# Altium schematic sheet 07b_V2.3.sch
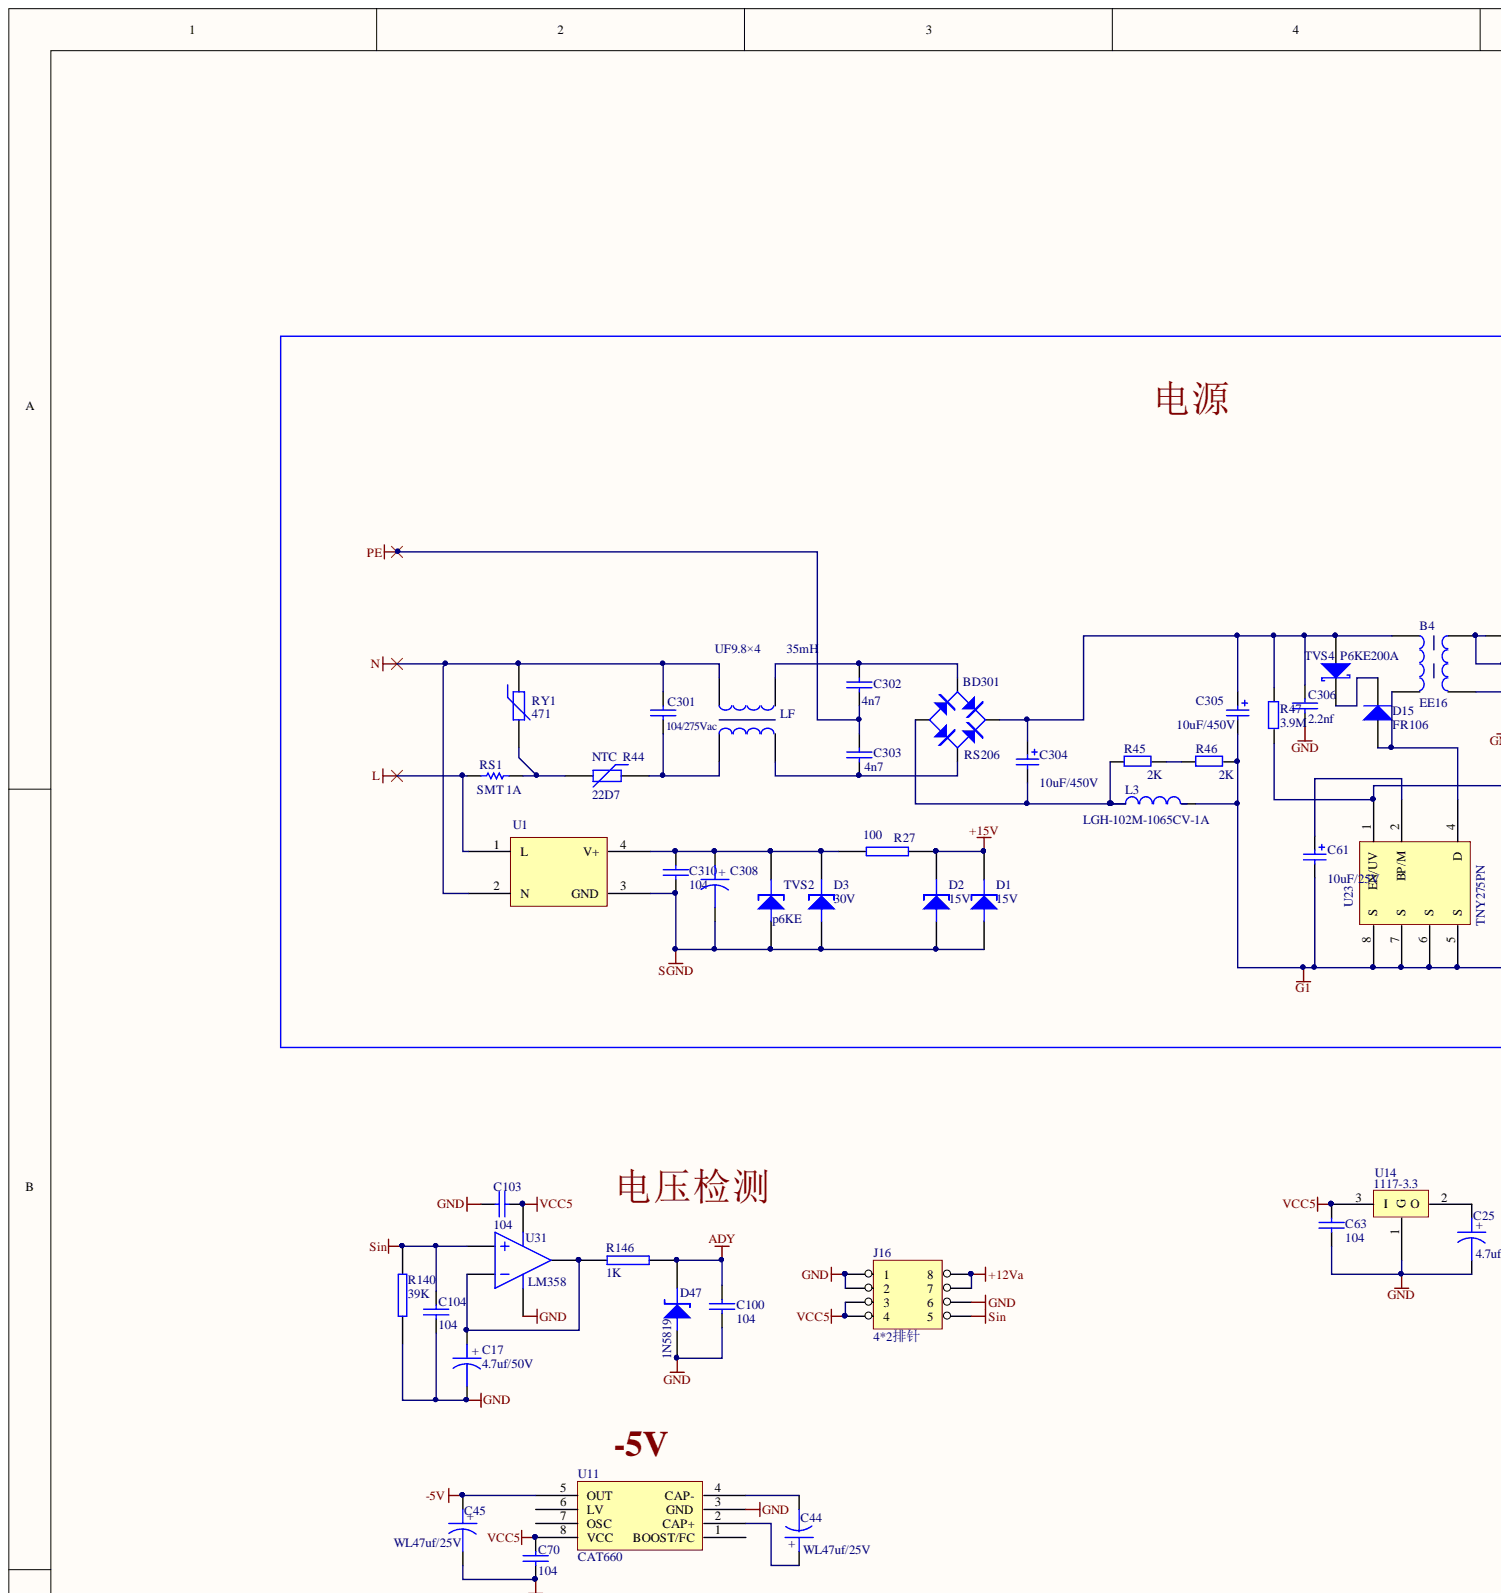
[diagram p0: 07b_V2.3.sch, top left]
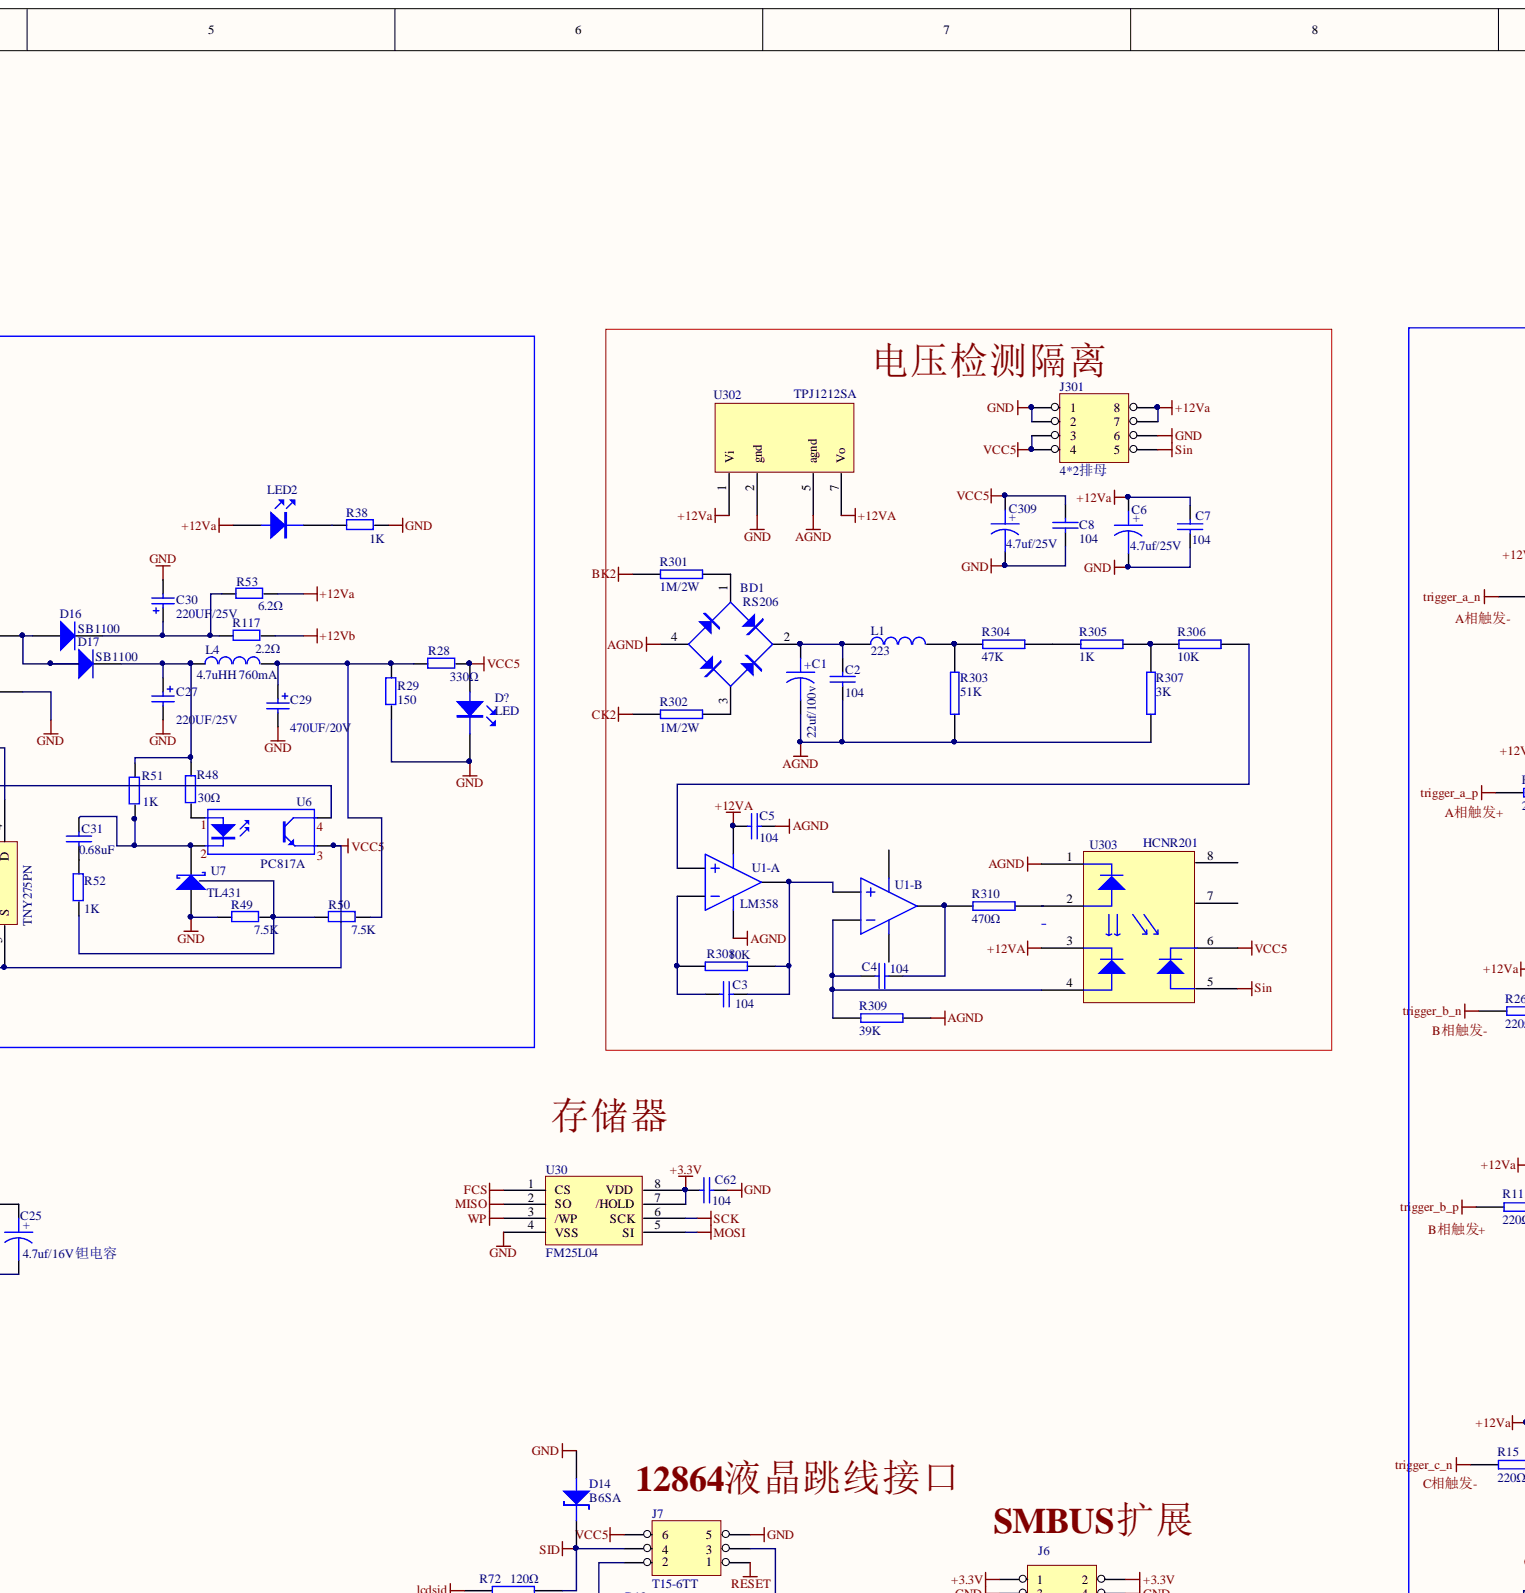
[diagram p1: 07b_V2.3.sch, top centre]
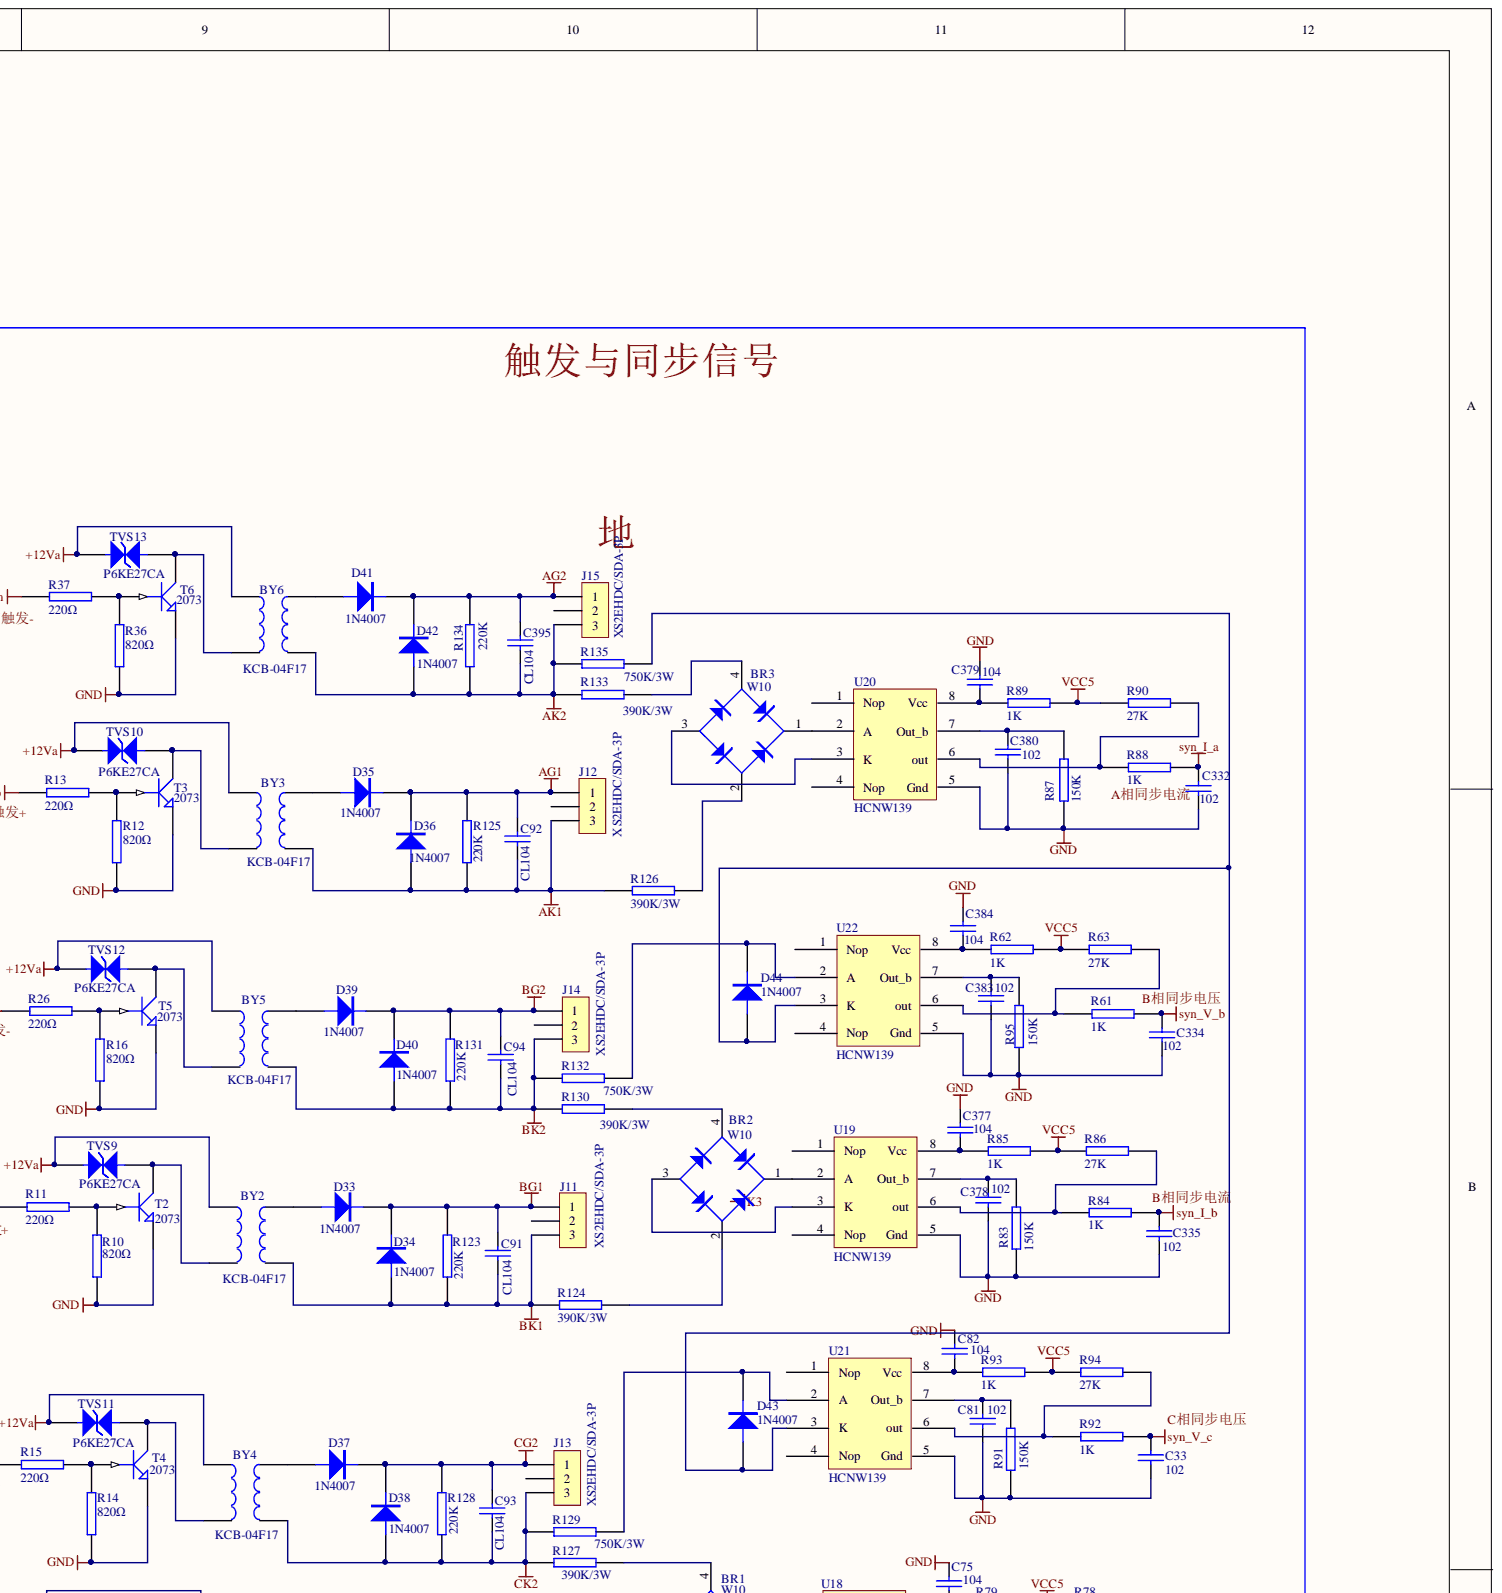
[diagram p2: 07b_V2.3.sch, top right]
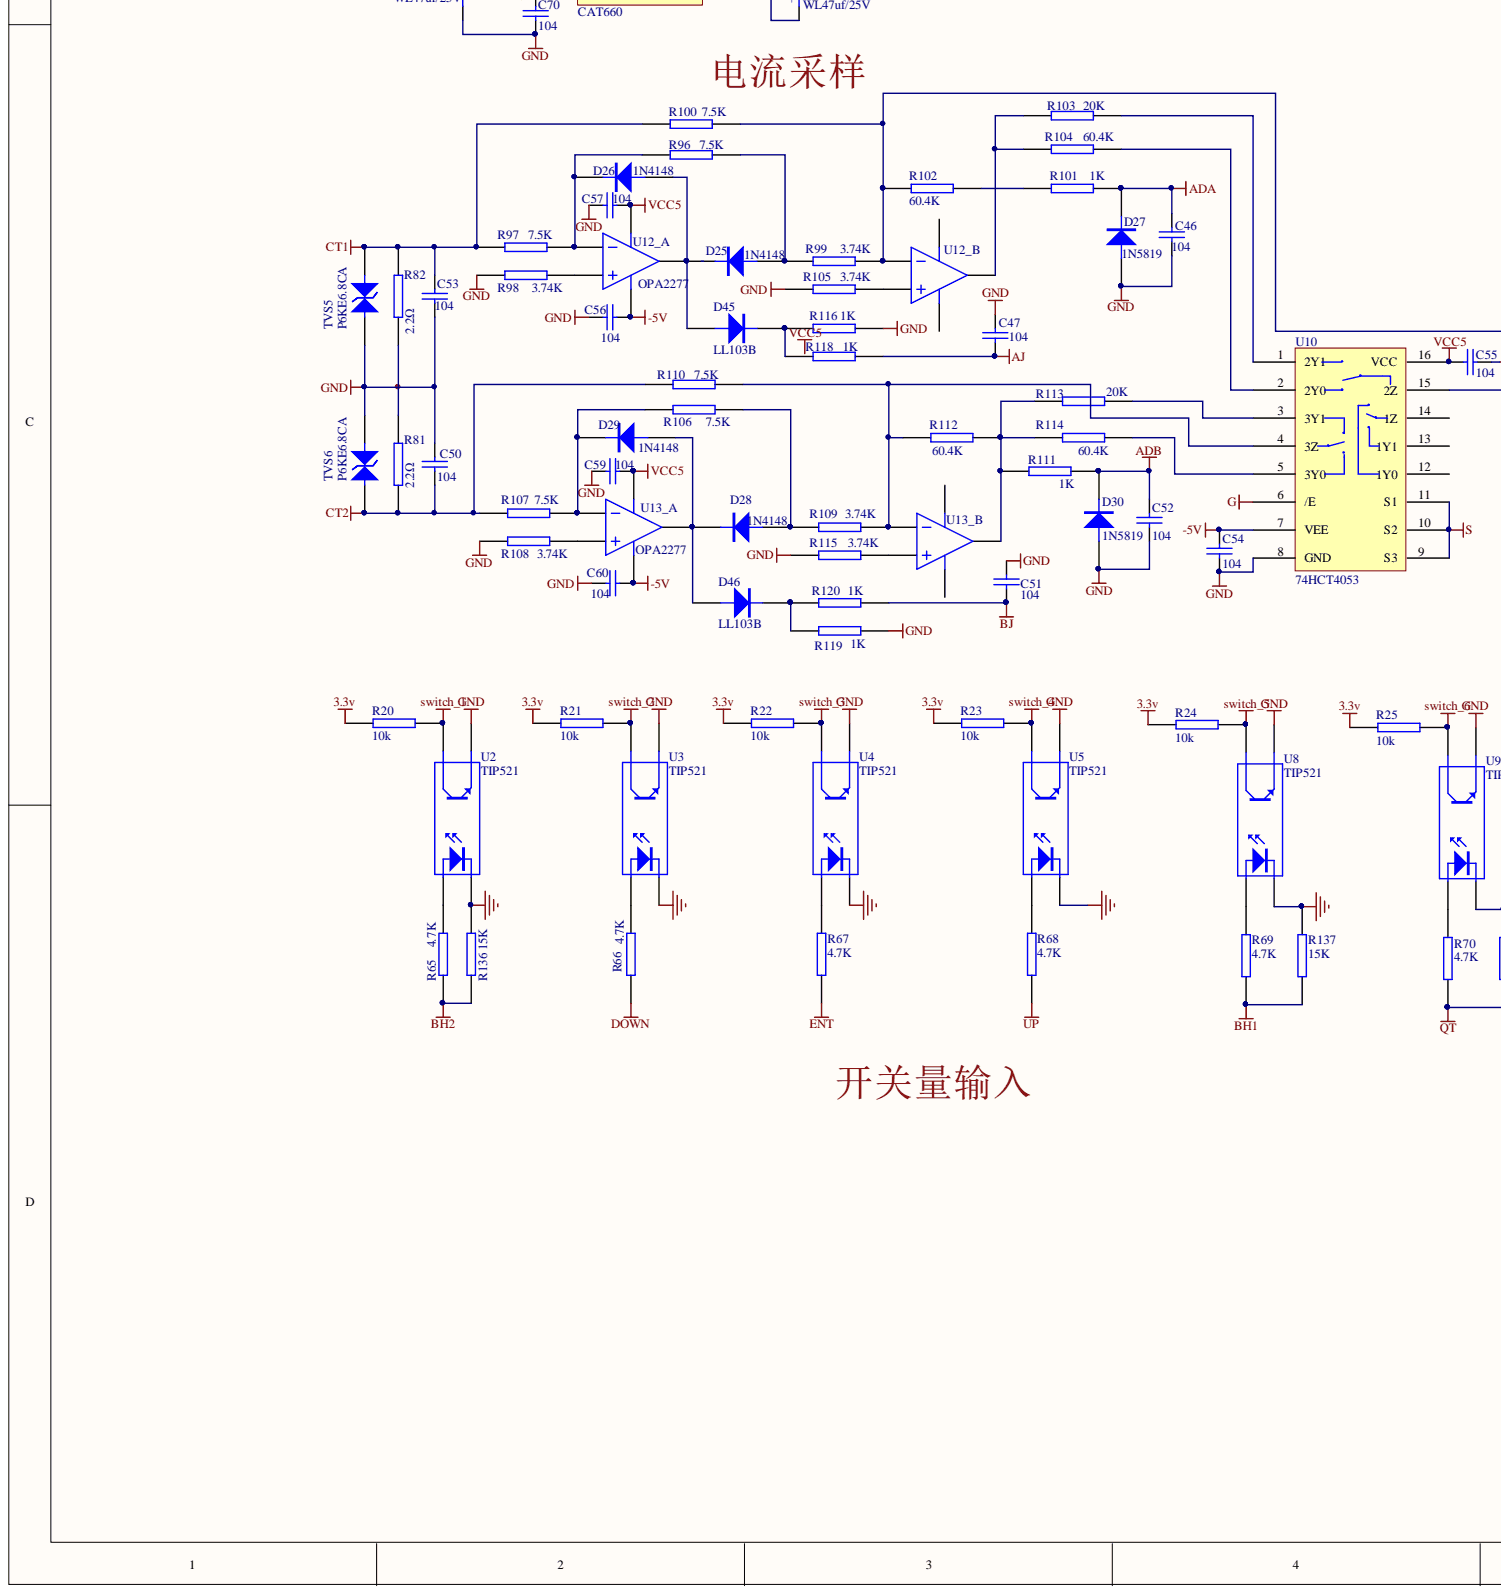
[diagram p3: 07b_V2.3.sch, bottom left]
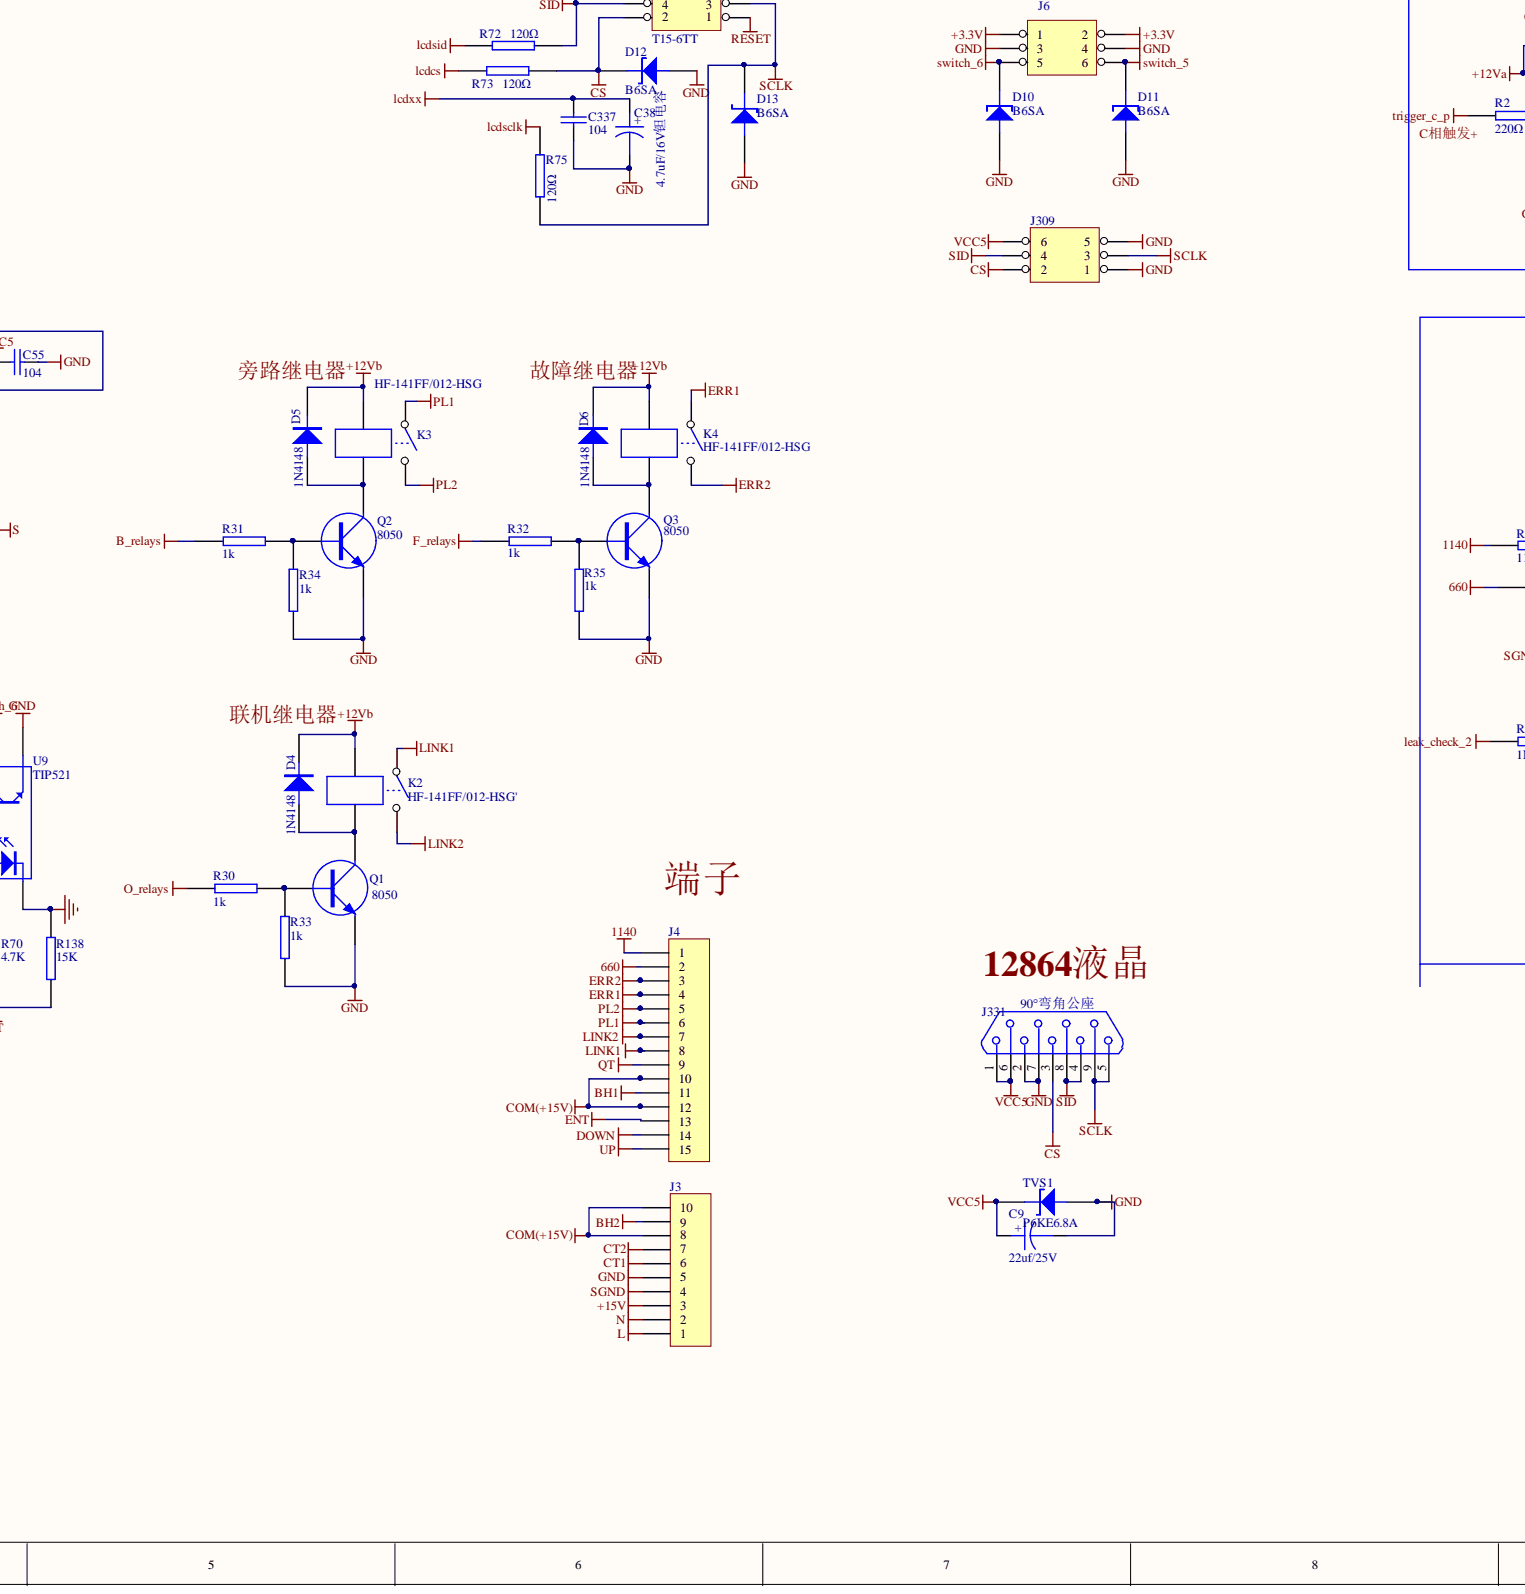
[diagram p4: 07b_V2.3.sch, bottom centre]
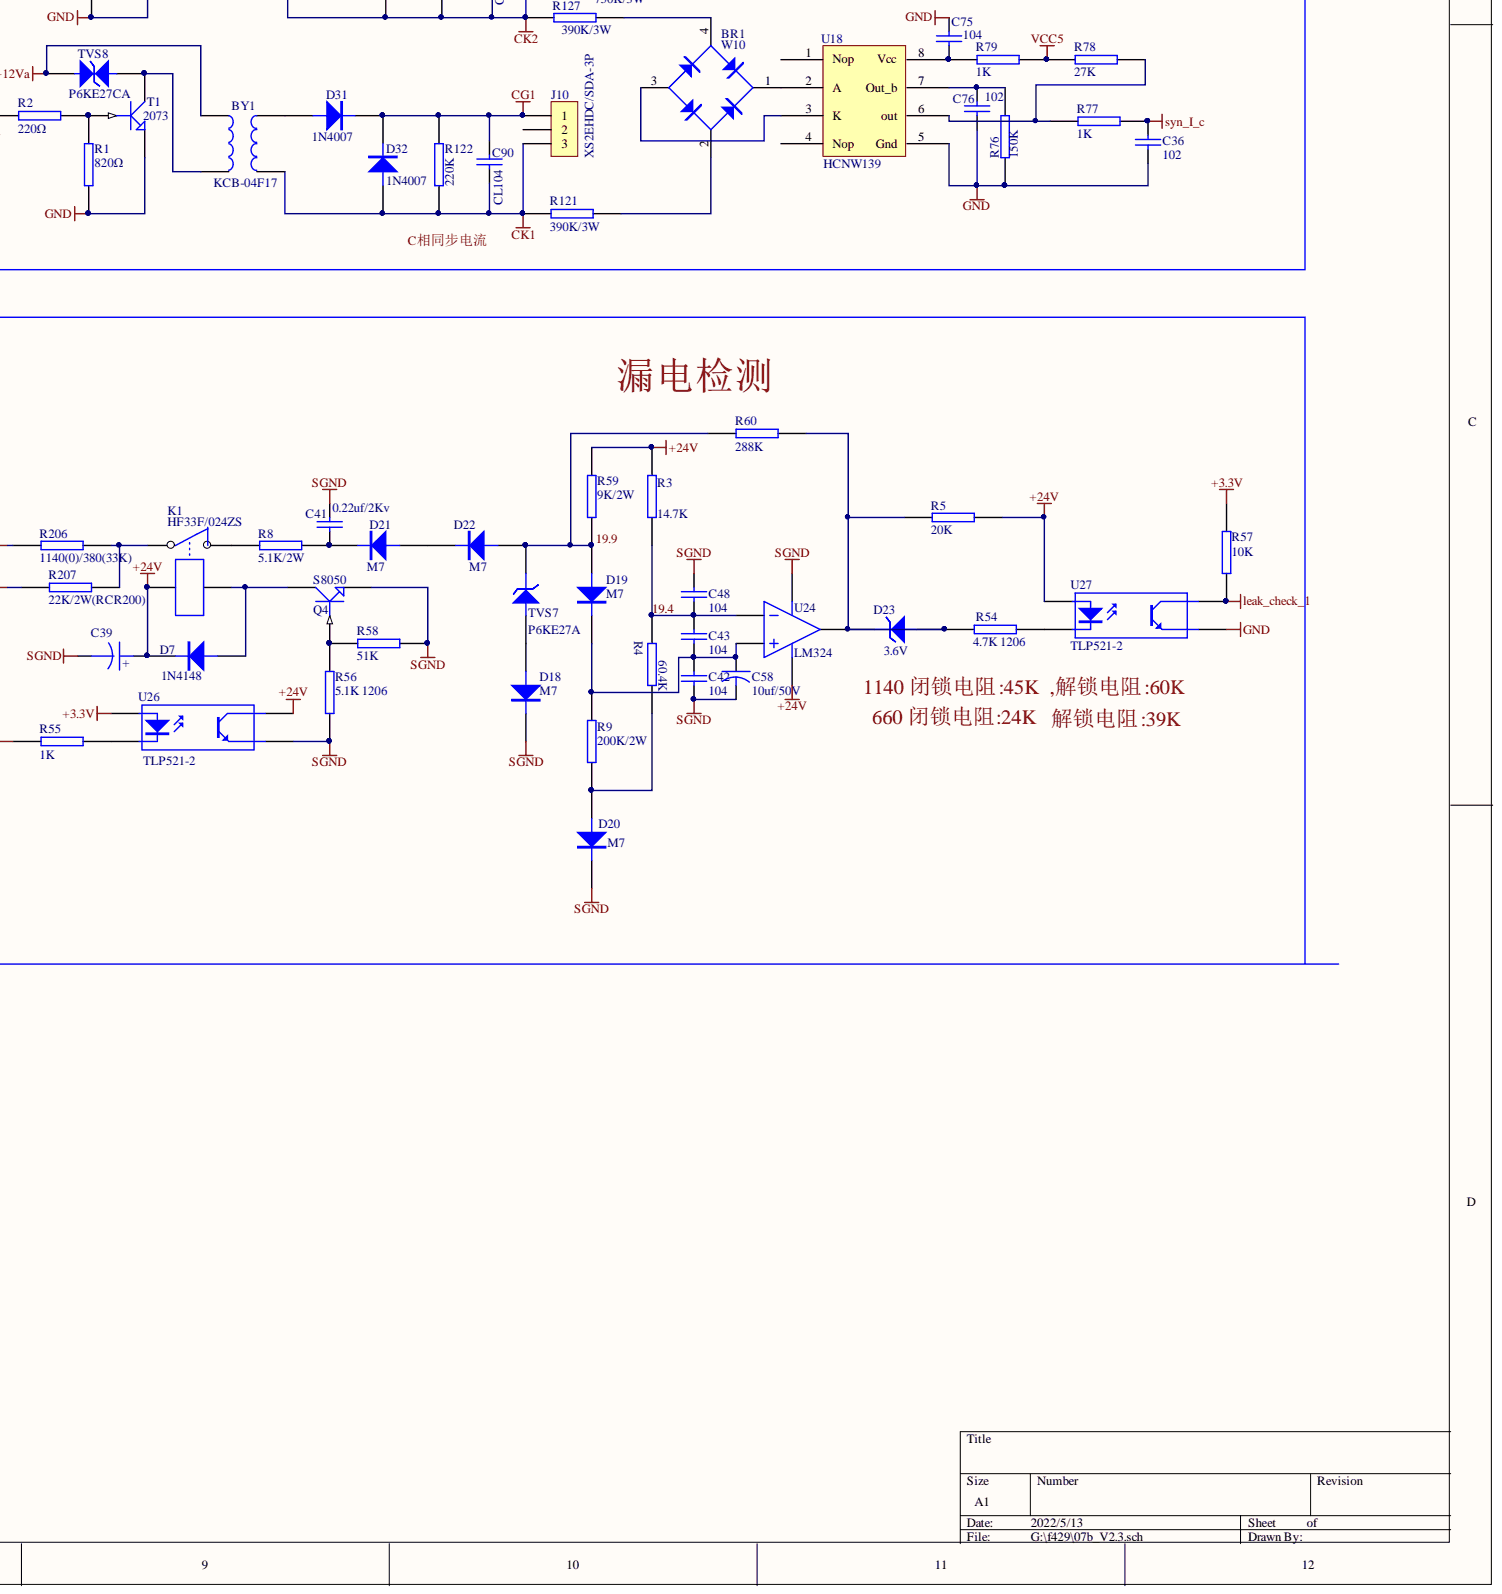
[diagram p5: 07b_V2.3.sch, bottom right]

## Parts
B4  EE16  [TRANS]
BD1  RS206  [BRIDGE]
BD301  RS206
BR1  W10  [BRIDGE]
BR2  W10  [BRIDGE]
BR3  W10  [BRIDGE]
BY1  KCB-04F17
BY2  KCB-04F17
BY3  KCB-04F17
BY4  KCB-04F17
BY5  KCB-04F17
BY6  KCB-04F17
C1  22uf/100v
C2  104
C3  104
C4  104
C5  104
C6  4.7uf/25V
C7  104
C8  104
C9  22uf/25V
C17  4.7uf/50V
C25  4.7uf/16V钽电容  [CC3225-1210]
C27  value 220UF/25V  [POLAR0.8]  (hidden comment: Cap Pol2)
C29  value 470UF/20V  [POLAR0.8]  (hidden comment: Cap Pol2)
C30  value 220UF/25V  [POLAR0.8]  (hidden comment: Cap Pol2)
C31  0.68uF
C33  102
C36  102
C38  4.7uF/16V钽电容
C39  (no value)
C41  0.22uf/2Kv
C42  104
C43  104
C44  WL47uf/25V
C45  WL47uf/25V
C46  104
C47  104
C48  104
C50  104
C51  104
C52  104
C53  104
C54  104
C55  104
C56  104
C57  104
C58  10uf/50V
C59  104
C60  104
C61  value 10uF/25V  [POLAR0.8]  (hidden comment: Cap Pol2)
C62  104
C63  104
C70  104
C75  104
C76  102
C81  102
C82  104
C90  CL104
C91  CL104
C92  CL104
C93  CL104
C94  CL104
C100  104
C103  104
C104  104
C301  104/275Vac
C302  4n7
C303  4n7
C304  value 10uF/450V  [POLAR0.8]  (hidden comment: Cap Pol2)
C305  value 10uF/450V  [POLAR0.8]  (hidden comment: Cap Pol2)
C306  2.2nf
C308  (no value)
C309  4.7uf/25V
C310  104
C332  102
C334  102
C335  102
C337  104
C377  104
C378  102
C379  104
C380  102
C383  102
C384  104
C395  CL104
D1  15V
D2  15V
D3  30V
D4  1N4148
D5  1N4148
D6  1N4148
D7  1N4148
D10  B6SA
D11  B6SA
D12  B6SA
D13  B6SA
D14  B6SA
D15  FR106  [SMC]
D16  SB1100  [SMC]
D17  SB1100  [SMC]
D18  M7
D19  M7
D20  M7
D21  M7
D22  M7
D23  3.6V
D25  1N4148
D26  1N4148
D27  1N5819
D28  1N4148
D29  1N4148
D30  1N5819
D31  1N4007
D32  1N4007
D33  1N4007
D34  1N4007
D35  1N4007
D36  1N4007
D37  1N4007
D38  1N4007
D39  1N4007
D40  1N4007
D41  1N4007
D42  1N4007
D43  1N4007
D44  1N4007
D45  LL103B
D46  LL103B
D47  1N5819
D?  LED
J3  (no value)
J4  (no value)
J6  (no value)
J7  T15-6TT
J10  XS2EHDC/SDA-3P
J11  XS2EHDC/SDA-3P
J12  XS2EHDC/SDA-3P
J13  XS2EHDC/SDA-3P
J14  XS2EHDC/SDA-3P
J15  XS2EHDC/SDA-3P
J16  4*2排针
J301  4*2排母
J309  (no value)
J331  90°弯角公座  [DB-9/M]
K1  HF33F/024ZS
K2  HF-141FF/012-HSG'
K3  HF-141FF/012-HSG
K4  HF-141FF/012-HSG
L1  223
L3  LGH-102M-1065CV-1A  [INDC1005-0402]
L4  4.7uHH 760mA  [INDC1005-0402]
LED2  (no value)
LF  UF9.8×4          35mH  [TRANS]
NTC_R44  22D7  [CR2012-0805]
Q1  8050
Q2  8050
Q3  8050
Q4  S8050
R1  820Ω
R2  220Ω
R3  14.7K
R4  60.4K
R5  20K
R8  5.1K/2W
R9  200K/2W
R10  820Ω
R11  220Ω
R12  820Ω
R13  220Ω
R14  820Ω
R15  220Ω
R16  820Ω
R20  10k
R21  10k
R22  10k
R23  10k
R24  10k
R25  10k
R26  220Ω
R27  100
R28  value 330Ω  [AXIAL-0.4]  (hidden comment: Res2)
R29  value 150  [AXIAL-0.4]  (hidden comment: Res2)
R30  1k
R31  1k
R32  1k
R33  1k
R34  1k
R35  1k
R36  820Ω
R37  220Ω
R38  value 1K  [AXIAL-0.4]  (hidden comment: Res2)
R45  value 2K  [AXIAL-0.4]  (hidden comment: Res2)
R46  value 2K  [AXIAL-0.4]  (hidden comment: Res2)
R47  value 3.9M  [AXIAL-0.4]  (hidden comment: Res2)
R48  value 30Ω  [AXIAL-0.4]  (hidden comment: Res2)
R49  value 7.5K  [AXIAL-0.4]  (hidden comment: Res2)
R50  value 7.5K  [AXIAL-0.4]  (hidden comment: Res2)
R51  value 1K  [AXIAL-0.4]  (hidden comment: Res2)
R52  value 1K  [AXIAL-0.4]  (hidden comment: Res2)
R53  value 6.2Ω  [AXIAL-0.4]  (hidden comment: Res2)
R54  4.7K 1206
R55  1K
R56  5.1K 1206
R57  10K
R58  51K
R59  9K/2W
R60  288K
R61  1K
R62  1K
R63  27K
R65  4.7K
R66  4.7K
R67  4.7K
R68  4.7K
R69  4.7K
R70  4.7K
R72  120Ω
R73  120Ω
R75  120Ω
R76  150K
R77  1K
R78  27K
R79  1K
R81  2.2Ω
R82  2.2Ω
R83  150K
R84  1K
R85  1K
R86  27K
R87  150K
R88  1K
R89  1K
R90  27K
R91  150K
R92  1K
R93  1K
R94  27K
R95  150K
R96  7.5K
R97  7.5K
R98  3.74K
R99  3.74K
R100  7.5K
R101  1K
R102  60.4K
R103  20K
R104  60.4K
R105  3.74K
R106  7.5K
R107  7.5K
R108  3.74K
R109  3.74K
R110  7.5K
R111  1K
R112  60.4K
R113  20K
R114  60.4K
R115  3.74K
R116  1K
R117  value 2.2Ω  [AXIAL-0.4]  (hidden comment: Res2)
R118  1K
R119  1K
R120  1K
R121  390K/3W
R122  220K
R123  220K
R124  390K/3W
R125  220K
R126  390K/3W
R127  390K/3W
R128  220K
R129  750K/3W
R130  390K/3W
R131  220K
R132  750K/3W
R133  390K/3W
R134  220K
R135  750K/3W
R136  15K
R137  15K
R138  15K
R140  39K
R146  1K
R206  1140(0)/380(33K)
R207  22K/2W(RCR200)
R301  1M/2W
R302  1M/2W
R303  51K
R304  47K
R305  1K
R306  10K
R307  3K
R308  10K  (value not drawn)
R309  39K
R310  470Ω
RS1  SMT 1A  [AXIAL-0.3]
RY1  471  [CR2012-0805]
T1  2073
T2  2073
T3  2073
T4  2073
T5  2073
T6  2073
TVS1  P6KE6.8A
TVS2  p6KE
TVS4  P6KE200A  [SFM-T2(3)/X1.7V]
TVS5  P6KE6.8CA
TVS6  P6KE6.8CA
TVS7  P6KE27A
TVS8  P6KE27CA
TVS9  P6KE27CA
TVS10  P6KE27CA
TVS11  P6KE27CA
TVS12  P6KE27CA
TVS13  P6KE27CA
U1  (no value)
U1-A  LM358
U1-B  (no value)
U2  TIP521
U3  TIP521
U4  TIP521
U5  TIP521
U6  PC817A  [DIP-4]
U7  TL431  [SFM-T2(3)/X1.7V]
U8  TIP521
U9  TIP521
U10  74HCT4053
U11  CAT660
U12_A  OPA2277
U12_B  (no value)
U13_A  OPA2277
U13_B  (no value)
U14  1117-3.3  [DSO-G3]
U18  HCNW139
U19  HCNW139
U20  HCNW139
U21  HCNW139
U22  HCNW139
U23  TNY275PN
U24  LM324
U26  TLP521-2
U27  TLP521-2
U30  FM25L04
U31  LM358
U302  TPJ1212SA
U303  HCNR201

## Nets
3.3v: R20.1 R21.1 R22.1 R23.1 R24.2 R25.1
660: J4.2 R207.1
1140: J4.1 R206.1
+3.3V: C62.1 J6.1 J6.2 R57.2 U26.1 U30.7(/HOLD) U30.8(VDD)
+12Va: BY1.1 BY2.1 BY3.1 BY4.1 BY5.1 BY6.1 C5.1 C6.1 C7.1 J16.2 J16.4 J301.2 J301.4 LED2.A R53.2 TVS8.2 TVS9.2 TVS10.2 TVS11.2 TVS12.2 TVS13.2 U1-A.5 U302.1(Vi) U302.7(Vo) U303.3
+12Vb: D4.2 D5.2 D6.2 K2.3 K3.3 K4.3 R117.2
+15V: D1.2 D2.2 J3.3 R27.2
+24V: C39.1 D7.2 K1.3 R3.2 R5.1 R59.1 U24.5 U26.3 U27.1
ADA: C46.1 D27.2 R101.2
ADB: C52.1 D30.2 R111.2
ADY: C100.1 D47.2 R146.2
AG1: C92.1 D35.2 D36.2 J12.1 R125.2
AG2: C395.1 D41.2 D42.2 J15.1 R134.2
AGND: BD1.4 C1.2 C2.2 C5.2 R303.1 R307.1 R309.1 U1-A.4 U302.5(agnd) U303.1
AJ: C47.2 R118.1
AK1: BY3.4 C92.2 D36.1 J12.3 R125.1 R126.1
AK2: BY6.4 C395.2 D42.1 J15.3 R133.1 R134.1 R135.1
B_relays: R31.1
BG1: C91.1 D33.2 D34.2 J11.1 R123.2
BG2: C94.1 D39.2 D40.2 J14.1 R131.2
BH1: J4.11 R69.2 R137.1
BH2: J3.9 R65.2 R136.1
BJ: C51.2 R120.2
BK1: BY2.4 C91.2 D34.1 J11.3 R123.1 R124.1
BK2: BY5.4 C94.2 D40.1 J14.3 R130.1 R131.1 R132.1 R301.1
CG1: C90.1 D31.2 D32.2 J10.1 R122.2
CG2: C93.1 D37.2 D38.2 J13.1 R128.2
CK1: BY1.4 C90.2 D32.1 J10.3 R121.1 R122.1
CK2: BY4.4 C93.2 D38.1 J13.3 R127.1 R128.1 R129.1 R302.1
COM(+15V): J3.8 J3.10 J4.10 J4.12
CS: D12.2 J7.2 J309.2 J331.3 R73.2
CT1: C53.2 J3.6 R82.2 R97.1 R100.1 TVS5.2
CT2: C50.1 J3.7 R81.1 R107.1 R110.1 TVS6.2
DOWN: J4.14 R66.2
ENT: J4.13 R67.2
ERR1: J4.4 K4.1
ERR2: J4.3 K4.2
F_relays: R32.1
FCS: U30.1(CS)
G: U10.6(/E)
G1: C8.1 C9.1 C55.2 C57.2 C59.2 C61.2 C63.1 C70.1 C103.1 C305.2 C309.1 D?.A J7.6 J16.5 J16.7 J301.5 J301.7 J309.6 J331.1 J331.6 L3.2 R28.2 R46.2 R62.2 R63.1 R78.1 R79.2 R85.2 R86.1 R89.2 R90.1 R93.2 R94.1 TVS1.2 U6.3 U10.16(VCC) U11.8(VCC) U12_A.4 U13_A.4 U14.3 U23.5(S) U23.6(S) U23.7(S) U23.8(S) U31.5 U303.6
GND: B4.4 C6.2 C7.2 C8.2 C9.2 C17.2 C25.2 C27.2 C29.2 C30.2 C33.2 C36.2 C38.2 C45.2 C46.2 C47.1 C50.2 C51.1 C52.2 C53.1 C54.1 C55.1 C56.1 C57.1 C59.1 C60.1 C62.2 C63.2 C70.2 C75.1 C76.2 C81.2 C82.1 C100.2 C103.2 C104.2 C306.2 C309.2 C332.2 C334.2 C335.2 C337.2 C377.1 C378.2 C379.1 C380.2 C383.2 C384.1 D10.1 D11.1 D12.1 D13.1 D14.1 D27.1 D30.1 D47.1 D?.K J3.5 J6.3 J6.4 J7.5 J16.1 J16.2 J16.6 J301.1 J301.2 J301.6 J309.1 J309.5 J331.2 J331.7 Q1.3 Q2.3 Q3.3 R1.1 R10.1 R12.1 R14.1 R16.1 R29.2 R33.1 R34.1 R35.1 R36.1 R38.2 R49.1 R76.2 R81.2 R82.1 R83.2 R87.2 R91.2 R95.2 R98.1 R105.1 R108.1 R115.1 R116.2 R119.2 R140.1 T1.3 T2.3 T3.3 T4.3 T5.3 T6.3 TVS1.1 TVS5.2 TVS6.2 U2.4 U3.4 U4.4 U5.4 U7.3 U8.4 U9.4 U10.8(GND) U11.3(GND) U14.1 U18.5(Gnd) U19.5(Gnd) U20.5(Gnd) U21.5(Gnd) U22.5(Gnd) U27.4 U30.4(VSS) U31.4 U302.2(gnd)
L: J3.1 RS1.1 U1.1(L)
lcdcs: R73.1
lcdsclk: R75.1
lcdsid: R72.1
lcdxx: C38.1 C337.1
leak_check_1: R57.1 U27.3
leak_check_2: R55.1
LINK1: J4.8 K2.1
LINK2: J4.7 K2.2
MISO: U30.2(SO)
MOSI: U30.5(SI)
N: C301.1 J3.2 LF.3 RY1.1 U1.2(N)
O_relays: R30.1
PE: C302.2 C303.1
PL1: J4.6 K3.1
PL2: J4.5 K3.2
QT: J4.9 R70.2 R138.1
RESET: J7.1
S: U10.9(S3) U10.10(S2) U10.11(S1)
SCK: U30.6(SCK)
SCLK: D13.2 J7.3 J309.3 J331.5 J331.9 R75.2
SGND: C39.2 C41.2 C42.2 C48.1 C58.2 C308.2 C310.2 D1.1 D2.1 D3.1 D18.2 D20.2 J3.4 Q4.3 R56.1 R58.2 TVS2.1 U1.3(GND) U24.4 U26.4
SID: D14.2 J7.4 J309.4 J331.4 J331.8 R72.2
Sin: C104.1 J16.8 J301.8 R140.2 U31.2 U303.5
switch_1: R20.2 U2.3
switch_2: R21.2 U3.3
switch_3: R22.2 U4.3
switch_4: R23.2 U5.3
switch_5: D11.2 J6.6 R24.1 U8.3
switch_6: D10.2 J6.5 R25.2 U9.3
syn_I_a: C332.1 R88.2
syn_I_b: C335.1 R84.2
syn_I_c: C36.1 R77.2
syn_V_b: C334.1 R61.2
syn_V_c: C33.1 R92.2
trigger_a_n: R37.1
trigger_a_p: R13.1
trigger_b_n: R26.1
trigger_b_p: R11.1
trigger_c_n: R15.1
trigger_c_p: R2.1
UP: J4.15 R68.2
VCC: R136.2 R137.2 R138.2 U2.2 U3.2 U4.2 U5.2 U8.2 U9.2
WP: U30.3(/WP)
19.4: C43.1 C48.2 R3.1 R4.2 U24.1
-5V: C45.1 C54.2 C56.2 C60.2 U10.7(VEE) U11.5(OUT) U12_A.5 U13_A.5
NetB4_1: B4.1 BD301.3 C304.1 C305.1 C306.1 R47.1 TVS4.3
NetB4_2: B4.2 D15.1 U23.4(D)
NetB4_3: B4.3 D16.1 D17.1
NetBD1_1: BD1.1 R301.2
NetBD1_2: BD1.2 C1.1 C2.1 L1.1
NetBD1_3: BD1.3 R302.2
NetBD301_1: BD301.1 C304.2 L3.1 R45.1
NetBD301_2: BD301.2 C302.1 LF.4
NetBD301_4: BD301.4 C303.2 LF.2
NetBR1_1: BR1.1 U18.2(A)
NetBR1_2: BR1.2 R121.2
NetBR1_3: BR1.3 U18.3(K)
NetBR1_4: BR1.4 R127.2
NetBR2_1: BR2.1 U19.2(A)
NetBR2_2: BR2.2 R124.2
NetBR2_3: BR2.3 U19.3(K)
NetBR2_4: BR2.4 R130.2
NetBR3_1: BR3.1 U20.2(A)
NetBR3_2: BR3.2 R126.2
NetBR3_3: BR3.3 U20.3(K)
NetBR3_4: BR3.4 R133.2
NetBY1_2: BY1.2 D31.1
NetBY1_3: BY1.3 T1.2 TVS8.2
NetBY2_2: BY2.2 D33.1
NetBY2_3: BY2.3 T2.2 TVS9.2
NetBY3_2: BY3.2 D35.1
NetBY3_3: BY3.3 T3.2 TVS10.2
NetBY4_2: BY4.2 D37.1
NetBY4_3: BY4.3 T4.2 TVS11.2
NetBY5_2: BY5.2 D39.1
NetBY5_3: BY5.3 T5.2 TVS12.2
NetBY6_2: BY6.2 D41.1
NetBY6_3: BY6.3 T6.2 TVS13.2
NetC3_1: C3.1 R308.1 U1-A.1
NetC3_2: C3.2 R308.2 U1-A.3 U1-B.2
NetC4_1: C4.1 R309.2 U1-B.1 U303.4
NetC4_2: C4.2 R310.1 U1-B.3
NetC17_1: C17.1 R146.1 U31.1 U31.3
NetC25_1: C25.1 U14.2
NetC27_1: C27.1 D17.2 L4.1 R48.2 R51.2
NetC29_1: C29.1 L4.2 R28.1 R29.1 R50.2
NetC30_1: C30.1 D16.2 R53.1 R117.1
NetC31_1: C31.1 R51.1 U6.2 U7.1
NetC31_2: C31.2 R52.2
NetC41_1: C41.1 D21.2 R8.2
NetC42_1: C42.1 C43.2 C58.1 D19.2 R9.2 U24.2
NetC44_1: C44.1 U11.2(CAP+)
NetC44_2: C44.2 U11.4(CAP-)
NetC61_1: C61.1 U23.2(BP/M)
NetC75_2: C75.2 R79.1 U18.8(Vcc)
NetC76_1: C76.1 R76.1 U18.7(Out_b)
NetC81_1: C81.1 R91.1 U21.7(Out_b)
NetC82_2: C82.2 R93.1 U21.8(Vcc)
NetC301_2: C301.2 LF.1 NTC_R44.1
NetC308_1: C308.1 C310.1 D3.2 R27.1 TVS2.2 U1.4(V+)
NetC377_2: C377.2 R85.1 U19.8(Vcc)
NetC378_1: C378.1 R83.1 U19.7(Out_b)
NetC379_2: C379.2 R89.1 U20.8(Vcc)
NetC380_1: C380.1 R87.1 U20.7(Out_b)
NetC383_1: C383.1 R95.1 U22.7(Out_b)
NetC384_2: C384.2 R62.1 U22.8(Vcc)
NetD4_1: D4.1 K2.4 Q1.2
NetD5_1: D5.1 K3.4 Q2.2
NetD6_1: D6.1 K4.4 Q3.2
NetD7_1: D7.1 K1.4 Q4.2
NetD15_2: D15.2 TVS4.1
NetD18_1: D18.1 TVS7.1
NetD19_1: D19.1 D22.1 R59.2 R60.1 TVS7.2
NetD20_1: D20.1 R4.1 R9.1
NetD21_1: D21.1 D22.2
NetD23_1: D23.1 R5.2 R54.1 R60.2 U24.3
NetD25_1: D25.1 R96.2 R99.1
NetD25_2: D25.2 D26.1 D45.1 U12_A.3
NetD26_2: D26.2 R96.1 R97.2 U12_A.1
NetD28_1: D28.1 R106.2 R109.1
NetD28_2: D28.2 D29.1 D46.1 U13_A.3
NetD29_2: D29.2 R106.1 R107.2 U13_A.1
NetD43_1: D43.1 D44.1 R135.2 U21.3(K) U22.3(K)
NetD43_2: D43.2 R129.2 U21.2(A)
NetD44_2: D44.2 R132.2 U22.2(A)
NetD45_2: D45.2 R116.1 R118.2
NetD46_2: D46.2 R119.1 R120.1
NetK1_1: K1.1 R206.2 R207.2
NetK1_2: K1.2 R8.1
NetL1_2: L1.2 R303.2 R304.1
NetLED2_K: LED2.K R38.1
NetNTC  R44_2: NTC_R44.2 RS1.2 RY1.2
NetQ1_1: Q1.1 R30.2 R33.2
NetQ2_1: Q2.1 R31.2 R34.2
NetQ3_1: Q3.1 R32.2 R35.2
NetQ4_1: Q4.1 R56.2 R58.1
NetR1_2: R1.2 R2.2 T1.1
NetR10_2: R10.2 R11.2 T2.1
NetR12_2: R12.2 R13.2 T3.1
NetR14_2: R14.2 R15.2 T4.1
NetR16_2: R16.2 R26.2 T5.1
NetR36_2: R36.2 R37.2 T6.1
NetR45_2: R45.2 R46.1
NetR47_2: R47.2 U6.4 U23.1(EN/UV)
NetR48_1: R48.1 U6.1
NetR49_2: R49.2 R50.1 R52.1
NetR54_2: R54.2 U27.2
NetR55_2: R55.2 U26.2
NetR61_1: R61.1 R63.2 U22.6(out)
NetR65_1: R65.1 U2.1
NetR66_1: R66.1 U3.1
NetR67_1: R67.1 U4.1
NetR68_1: R68.1 U5.1
NetR69_1: R69.1 U8.1
NetR70_1: R70.1 U9.1
NetR77_1: R77.1 R78.2 U18.6(out)
NetR84_1: R84.1 R86.2 U19.6(out)
NetR88_1: R88.1 R90.2 U20.6(out)
NetR92_1: R92.1 R94.2 U21.6(out)
NetR98_2: R98.2 U12_A.2
NetR99_2: R99.2 R100.2 R102.1 U10.15(2Z) U12_B.1
NetR101_1: R101.1 R102.2
NetR103_1: R103.1 U10.1(2Y1)
NetR103_2: R103.2 R104.1 U12_B.3
NetR104_2: R104.2 U10.2(2Y0)
NetR105_2: R105.2 U12_B.2
NetR108_2: R108.2 U13_A.2
NetR109_2: R109.2 R110.2 R112.1 U10.4(3Z) U13_B.1
NetR111_1: R111.1 R112.2 R113.1 R114.1 U13_B.3
NetR113_2: R113.2 U10.3(3Y1)
NetR114_2: R114.2 U10.5(3Y0)
NetR115_2: R115.2 U13_B.2
NetR304_2: R304.2 R305.2
NetR305_1: R305.1 R306.1 R307.2
NetR306_2: R306.2 U1-A.2
NetR310_2: R310.2 U303.2
1:
2:
3:
4:
19.9:
660 闭锁电阻:24K:
1140 闭锁电阻:45K:
12864液晶:
12864液晶跳线接口:
,解锁电阻:60K:
A相同步电流:
A相触发+:
A相触发-:
B相同步电压:
B相同步电流:
B相触发+:
B相触发-:
C相同步电压:
C相同步电流:
C相触发+:
C相触发-:
K3:
N00216:
N00217:
N00218:
N00219:
N00220:
SMBUS扩展:
地:
存储器:
开关量输入:
故障继电器:
旁路继电器:
漏电检测:
电压检测:
电压检测隔离:
电流采样:
电源:
端子:
联机继电器:
解锁电阻:39K:
触发与同步信号:

Not connected: D23 2; J10 2; J11 2; J12 2; J13 2; J14 2; J15 2; U1-B 4 5; U10 12(1Y0) 13(1Y1) 14(1Z); U11 1(BOOST/FC) 6(LV) 7(OSC); U12_B 4 5; U13_B 4 5; U18 1(Nop) 4(Nop); U19 1(Nop) 4(Nop); U20 1(Nop) 4(Nop); U21 1(Nop) 4(Nop); U22 1(Nop) 4(Nop); U303 7 8

## Library data of the parts
B4 LF = Trans: Transformer; Component Kind: Standard; LatestRevisionDate: 17-Jul-2002; LatestRevisionNote: Re-released for DXP Platform.; Published: 24-Mar-1999; Publisher: Altium Limited
BD1 BR1-BR3 = BRIDGE1: Diode Bridge; Component Kind: Standard
BD301 = 18DB05: Diode Bridge; Component Kind: Standard
BY1-BY6 = TRANS3: Component Kind: Standard
C1 C6 C9 C17 C25 C38 C39 C44 C45 C308 C309 = CAPACITOR POL: Capacitor; Component Kind: Standard
C2-C5 C7 C8 C31 C33 C36 C41-C43 C46-C48 C50-C57 C59 C60 C62 C63 C70 C75 C76 C81 C82 C90-C94 C100 C103 C104 C301-C303 C306 C310 C332 C334 C335 C337 C377-C380 C383 C384 C395 = CAP: Capacitor; Component Kind: Standard
C27 C29 C30 C61 C304 C305 = Cap Pol2: Polarized Capacitor (Axial); Component Kind: Standard; LatestRevisionDate: 17-Jul-2002; LatestRevisionNote: Re-released for DXP Platform.; Library Name: Miscellaneous Devices.IntLib; PackageDescription: Polarized Capacitor; 2 Leads; PackageReference: POLAR0.8; Published: 8-Jun-2000; Signal Integrity: Cap Pol; Simulation: CAP; Publisher: Altium Limited
C58 = CAPACITOR: Component Kind: Standard; Description: Capacitor
D1-D3 D10 D11 TVS2 = TUNNEL: Tunnel FDiode; Component Kind: Standard
D4-D7 D18-D22 D25-D46 = DIODE: Component Kind: Standard; Description: Diode
D12-D14 D47 TVS1 = ZENER2: Zener Diode; Component Kind: Standard
D15-D17 = Diode: Default Diode; Code_JEDEC: DO-214-AB; Component Kind: Standard; LatestRevisionDate: 17-Jul-2002; LatestRevisionNote: Re-released for DXP Platform.; Library Name: Miscellaneous Devices.IntLib; PackageDescription: DO-214-AB/SMC; 2 C-Bend Leads; Body 7.9 x 5.9 mm, inc. leads (LxW); PackageReference: SMC; PackageVersion: Sep-1996; Published: 8-Jun-2000; Signal Integrity: Diode; Simulation: DIODE; Publisher: Altium Limited
D23 TVS7 = ZENER3: Zener Diode; Component Kind: Standard
J3 = CON10: Connector; Component Kind: Standard
J4 = CON15: Connector; Component Kind: Standard
J6 J7 J309 = HEADER 3X2: Component Kind: Standard
J10-J15 = CON3: Connector; Component Kind: Standard
J16 J301 = HEADER 4X2: Component Kind: Standard
J331 = DB9: Component Kind: Standard
K1 U303 = Component_1: Component Kind: Standard
K2-K4 = RELAY-SPST: Component Kind: Standard
L1 = INDUCTOR: Component Kind: Standard
L3 L4 = Inductor: Code_IEC: 1005; Code_JEITA: 0402; Component Kind: Standard; Description: Inductor; LatestRevisionDate: 17-Jul-2002; LatestRevisionNote: Re-released for DXP Platform.; PackageReference: 0402-A; Published: 8-Jun-2000; Publisher: Altium Limited
LED2 = LED: Component Kind: Standard
NTC_R44 RY1 = Res Varistor: Varistor (Voltage-Sensitive Resistor); Component Kind: Standard; LatestRevisionDate: 4-Mar-2005; LatestRevisionNote: PCB Footprint replaced.; Published: 24-Mar-1999; Publisher: Altium Limited
Q1-Q3 = NPN: NPN Transistor; Component Kind: Standard
Q4 T1-T6 = NPN1: NPN Transistor; Component Kind: Standard
R1-R5 R8-R16 R20-R27 R30-R37 R54-R63 R65-R70 R72 R73 R75-R79 R81-R116 R118-R138 R140 R146 R206 R207 R301-R310 = RES2: Component Kind: Standard
R28 R29 R38 R45-R53 R117 = Res2: Resistor; Component Kind: Standard; LatestRevisionDate: 17-Jul-2002; LatestRevisionNote: Re-released for DXP Platform.; Library Name: Miscellaneous Devices.IntLib; PackageDescription: Axial Device, Thru-Hole; 2 Leads; 0.4 in Pin Spacing; PackageReference: AXIAL-0.4; Published: 8-Jun-2000; Signal Integrity: Res; Simulation: RESISTOR; Publisher: Altium Limited
RS1 = Res1: Resistor; Component Kind: Standard; LatestRevisionDate: 17-Jul-2002; LatestRevisionNote: Re-released for DXP Platform.; Published: 8-Jun-2000; Publisher: Altium Limited
TVS4 U7 = Diode 10TQ040: Schottky Rectifier; Note: Terminal 2 is the TAB and is electrically connected to the cathode; Component Kind: Standard; ComponentLink1Description: Manufacturer Link; ComponentLink1URL: http://www.irf.com/; DatasheetDocument: PD-2.057B; LatestRevisionDate: 17-Jul-2002; LatestRevisionNote: Re-released for DXP Platform.; PackageDocument: Feb-1998; PackageReference: TO-220AC; Published: 8-Jun-2000; Publisher: Altium Limited
TVS5 TVS6 = P6KECA: Component Kind: Standard
TVS8-TVS13 = COMPONENT2: Component Kind: Standard
U1 = FAS-3: Component Kind: Standard
U1-A U1-B U12_A U12_B U13_A U13_B U24 U31 = OPAMP: Operational Amplifier; Component Kind: Standard
U2-U5 U8 U9 U26 U27 = OPTOISO1: Optoisolator; Component Kind: Standard
U6 = Optoisolator1: 4-Pin Phototransistor Optocoupler; Component Kind: Standard; DatasheetDocument: Nov-2003; LatestRevisionDate: 4-Mar-2005; LatestRevisionNote: Sch pins 3 & 4 Swapped.; PackageReference: DIP-4; Published: 24-Mar-1999; Publisher: Altium Limited
U14 = COMPONENT_3: Component Kind: Standard
U18-U22 = 6N139: Component Kind: Standard
D? = LED: Component Kind: Standard
U10 = 74HCT4053: Component Kind: Standard
U11 = CAT660: Component Kind: Standard
U23 = TNY275PN: Component Kind: Standard
U30 = FM25L04: Component Kind: Standard
U302 = TPJ1212SA: Component Kind: Standard
